# Altium schematic sheet Diode Controllers.SchDoc
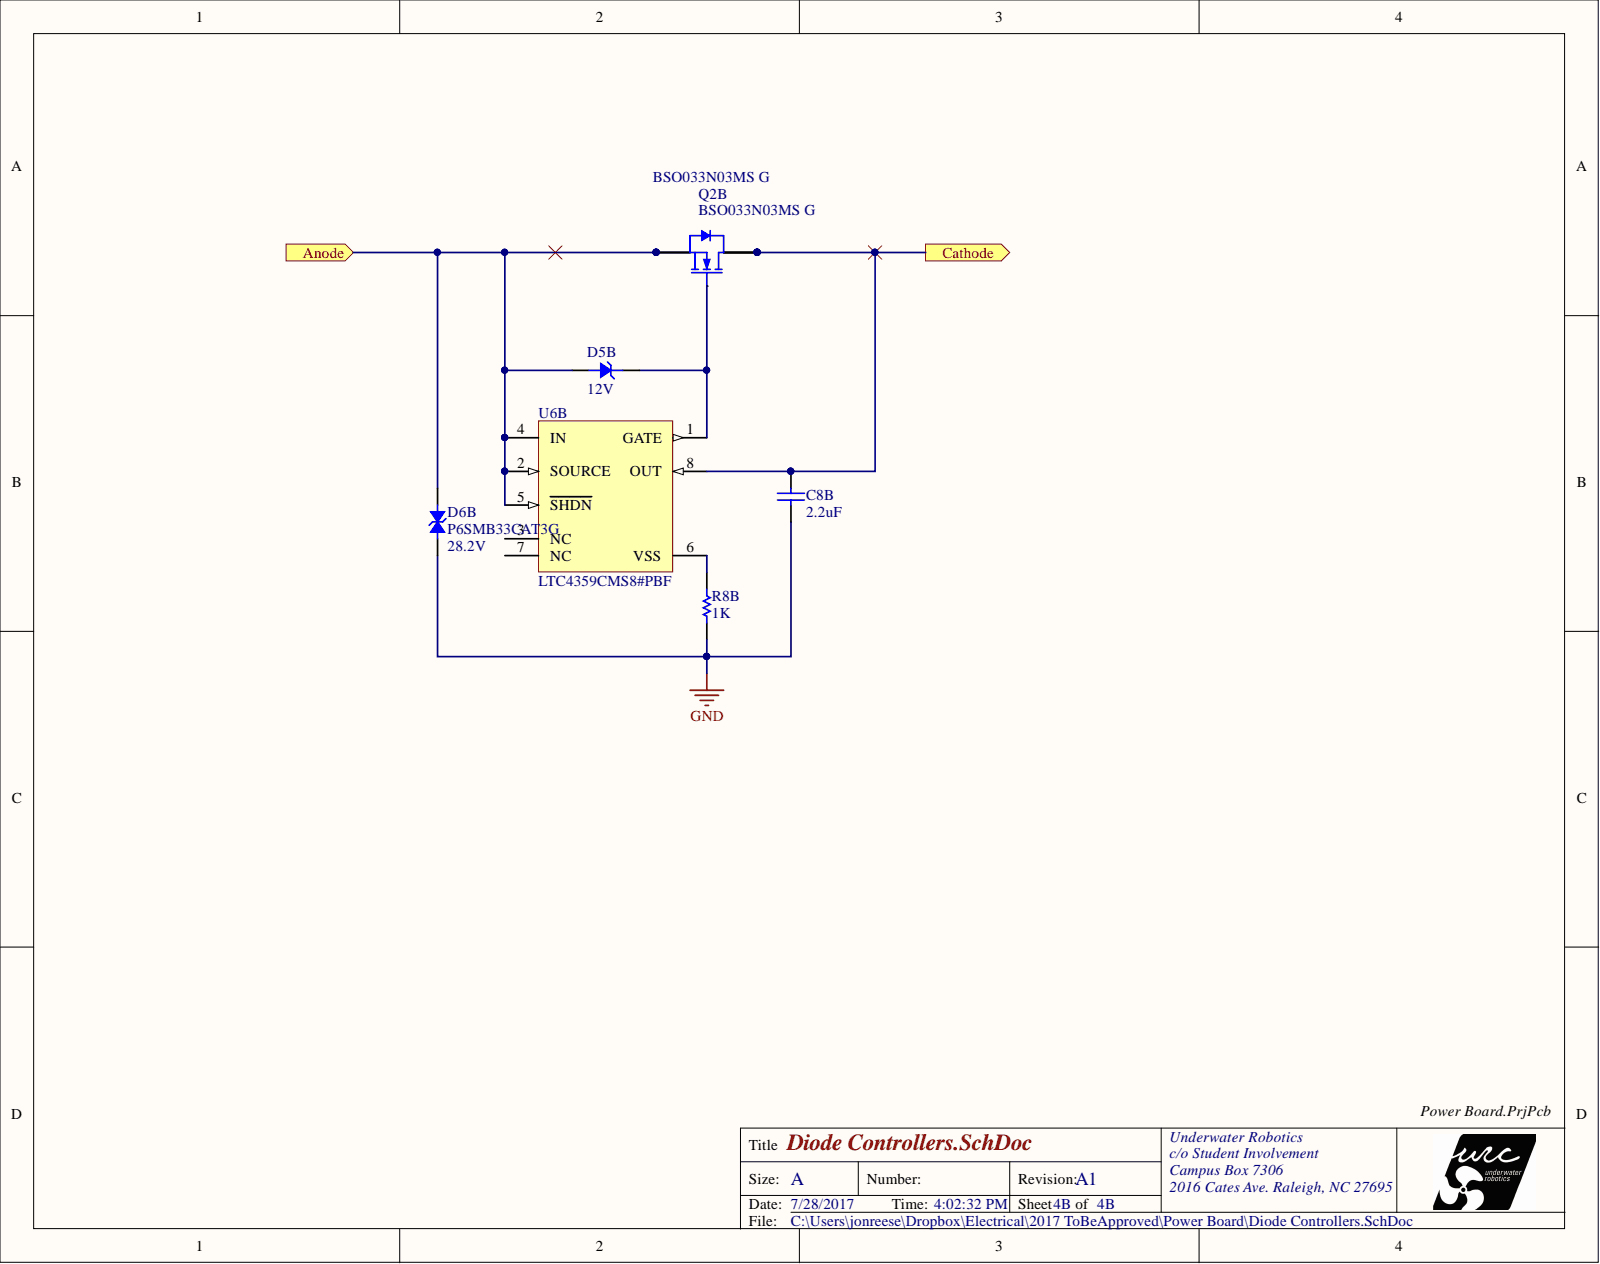
[diagram p0: Diode Controllers.SchDoc]

## Parts
C8B  value 2.2uF  [C1206]  (hidden comment: 2.2uF, C1206 TY , Ceramic MLCC)
D5B  Zener Voltage Vz Typ: 12V  [ONSC-SOD-523-2-502-01_C_V]  (hidden comment: MM5Z12VT1G, 12V Zener)
D6B  value 28.2V  Manufacturer Part Number: P6SMB33CAT3G  [ONSC-SMB-2-403A-03_H_V]  (hidden comment: Bidirectional Zener)
Q2B  BSO033N03MS G  [INF-PG-DSO-8-3_N]
R8B  value 1K  [R0603]  (hidden comment: Resistor)
U6B  LTC4359CMS8#PBF  [LT-MS8-8_N]

## Ports
Anode, Cathode

## Nets
GND: C8B.1 D6B.2 R8B.1
Anode: D5B.1 D6B.1 Q2B.1 Q2B.2 Q2B.3 U6B.2(SOURCE) U6B.4(IN) U6B.5(SHDN) ; port Anode
Cathode: C8B.2 Q2B.5 Q2B.6 Q2B.7 Q2B.8 U6B.8(OUT) ; port Cathode
NetD5B_2: D5B.2 Q2B.4 U6B.1(GATE)
NetR8B_2: R8B.2 U6B.6(VSS)
NetU6B_3: U6B.3(NC)
NetU6B_7: U6B.7(NC)

## Library data of the parts
C8B = 2.2uF, C1206 TY , Ceramic MLCC: Ceramic MLCC, Taiyo Yuden, X7R Dielectric; Manufacturer Part Number: UMK316F225ZG-T; Description 1: TAIYO YUDEN - UMK316F225ZG-T - CERAMIC CAPACITOR, 2.2UF, 50V, Y5V, +80,-20%, 1206; Component Kind: Standard; ComponentLink1Description: http://www.yuden.co.jp/productdata/catalog/en/mlcc_pack_e.pdf; ComponentLink1URL: http://www.yuden.co.jp/productdata/catalog/en/mlcc_pack_e.pdf; ComponentLink2Description: http://www.farnell.com/datasheets/1481447.pdf; ComponentLink2URL: http://www.farnell.com/datasheets/1481447.pdf; Library Name: Capacitors SchLib.SchLib; Manufacturer 1: TAIYO YUDEN; Pricing 1: 1=0.055, 10=0.055, 50=0.055, 100=0.055, 500=0.055, 1000=0.05 (USD); RoHS: YES; Stock 1: 1843; Supplier 1: Newark; Supplier Part Number 1: 08P5201
D5B = MM5Z12VT1G, 12V Zener: Zener diode; Manufacturer 1: ON SEMICONDUCTOR; Description 1: ON SEMICONDUCTOR - MM5Z12VT1G - ZENER DIODE, 200mW, 12V, SOD-523; Diode Case Style: SOD-523; Filter Terminals: SMD; No. of Pins: 2; Power Dissipation Pd: 200; Component Kind: Standard; ComponentLink1Description: http://www.farnell.com/datasheets/1840567.pdf; ComponentLink1URL: http://www.farnell.com/datasheets/1840567.pdf; Manufacturer Part Number: MM5Z12VT1G; Operating Temperature Max: 150 C; Packaging: Cut Tape; Pricing 1: 1=0.249, 50=0.162, 100=0.068, 250=0.066, 500=0.064, 1000=0.046, 3000=0.037, 9000=0.03 (USD); RoHS: YES; Stock 1: 4492; Supplier 1: Newark; Supplier Part Number 1: 09R9512; Zener Tolerance: -; Library Name: URC.SchLib
D6B = P6SMB33CAT3G 28.2V Bidirectional Zener: Bidirectional Zener diode; Manufacturer 1: ON SEMICONDUCTOR; Description 1: ON SEMICONDUCTOR - P6SMB33CAT3G - TVS DIODE, 600W, 33V, SMB; Component Kind: Standard; ComponentLink1Description: http://www.farnell.com/datasheets/1576153.pdf; ComponentLink1URL: http://www.farnell.com/datasheets/1576153.pdf; Pricing 1: 1=0.543, 50=0.36, 100=0.202, 250=0.195, 500=0.19, 1000=0.151, 2500=0.13, 7500=0.126 (USD); RoHS: YES; Stock 1: 6401; Supplier 1: Newark; Supplier Part Number 1: 42K2552; Library Name: URC.SchLib
Q2B = BSO033N03MS G: N-Channel OptiMOS 3 M-Series Power-MOSFET, 30 V VDS, 22 A ID, -55 to 150 degC, PG-DSO-8-16, Reel, Green; Manufacturer 1: INFINEON; Continuous Drain Current Id: 17; Description 1: INFINEON - BSO033N03MS G - MOSFET, N CHANNEL, 30V, 17A, 8DSO; Drain Source Voltage Vds: 30; No. of Pins: 8; On Resistance Rds(on): 0.0028; Rds(on) Test Voltage Vgs: 10; Threshold Voltage Vgs: 2; Transistor Polarity: N Channel; Component Kind: Standard; ComponentLink1Description: http://www.farnell.com/datasheets/1648231.pdf; ComponentLink1URL: http://www.farnell.com/datasheets/1648231.pdf; Library Name: Infineon Power MOSFET.SchLib; Manufacturer Part Number: BSO033N03MS G; Pricing 1: 1=0.868, 10=0.742, 25=0.662, 100=0.594, 250=0.525, 500=0.445, 1000=0.389, 2500=0.358 (USD); RoHS: YES; Stock 1: 1339; Supplier 1: Newark; Supplier Part Number 1: 47W3322
R8B = 1k, 5%, 100mW, Yageo, R0603: Manufacturer 1: YAGEO; Manufacturer Part Number: RC0603JR-131KL; Body Height: 0.45; Description 1: YAGEO - RC0603JR-131KL - RES, THICK FILM, 1K, 5%, 0.1W, 0603; Power Rating: 100; Product Range: RC Series; Resistance: 1; Resistance Tolerance: 5%; Resistor Case Style: 0603 [1608 Metric]; Voltage Rating: 50; Component Kind: Standard; ComponentLink1Description: http://www.farnell.com/datasheets/1868787.pdf; ComponentLink1URL: http://www.farnell.com/datasheets/1868787.pdf; ComponentLink2Description: http://www.yageo.com/pdf/PYu-RC0603_51_RoHS_L_4.pdf; ComponentLink2URL: http://www.yageo.com/pdf/PYu-RC0603_51_RoHS_L_4.pdf; Description: Resistor; Library Name: Resistors SchLib.SchLib; MSL: -; Packaging: Cut Tape; Pricing 1: 1=0.06, 10=0.011, 25=0.008, 100=0.005, 250=0.003, 1000=0.002 (USD); RoHS: YESX; Stock 1: 36430; Supplier 1: Newark; Supplier Part Number 1: 68R0220; Tolerance: 5%
U6B = LTC4359CMS8#PBF: Ideal Diode Controller with Reverse Input Protection, 4 to 80 V Vin, 8-pin SOP (MS8-8), 0 to 70 degC, Pb-Free; Manufacturer 1: Linear Technology; Current - Supply: 150µA; Delay Time - OFF: 300ns; Delay Time - ON: 200µs; Description 1: IC OR CTRLR N+1 8MSOP; FET Type: N-Channel; Internal Switch(s): No; Ratio - Input: N:1; Voltage - Supply: 4 V ~ 80 V; Applications: Automotive, Redundant Power Supplies, Telecom Infrastructure; Category: Integrated Circuits (ICs); Component Kind: Standard; ComponentLink1Description: http://www.linear.com/docs/41608; ComponentLink1URL: http://www.linear.com/docs/41608; Current - Output (Max): -; Library Name: Linear Technology Power Management Power Control.IntLib; Manufacturer Part Number: LTC4359CMS8#PBF; Mounting Type: Surface Mount; Operating Temperature: 0°C ~ 70°C; Package / Case: 8-TSSOP, 8-MSOP (0.118", 3.00mm Width); Packaging: Tube; Pricing 1: 1=4.5, 25=3, 100=2.55 (USD); RoHS: RoHS Compliant; Stock 1: 223; Supplier 1: Digi-Key; Supplier Device Package: 8-MSOP; Supplier Part Number 1: LTC4359CMS8#PBF-ND; Type: N+1 ORing Controller
